# Altium schematic sheet AD.SchDoc(AD)
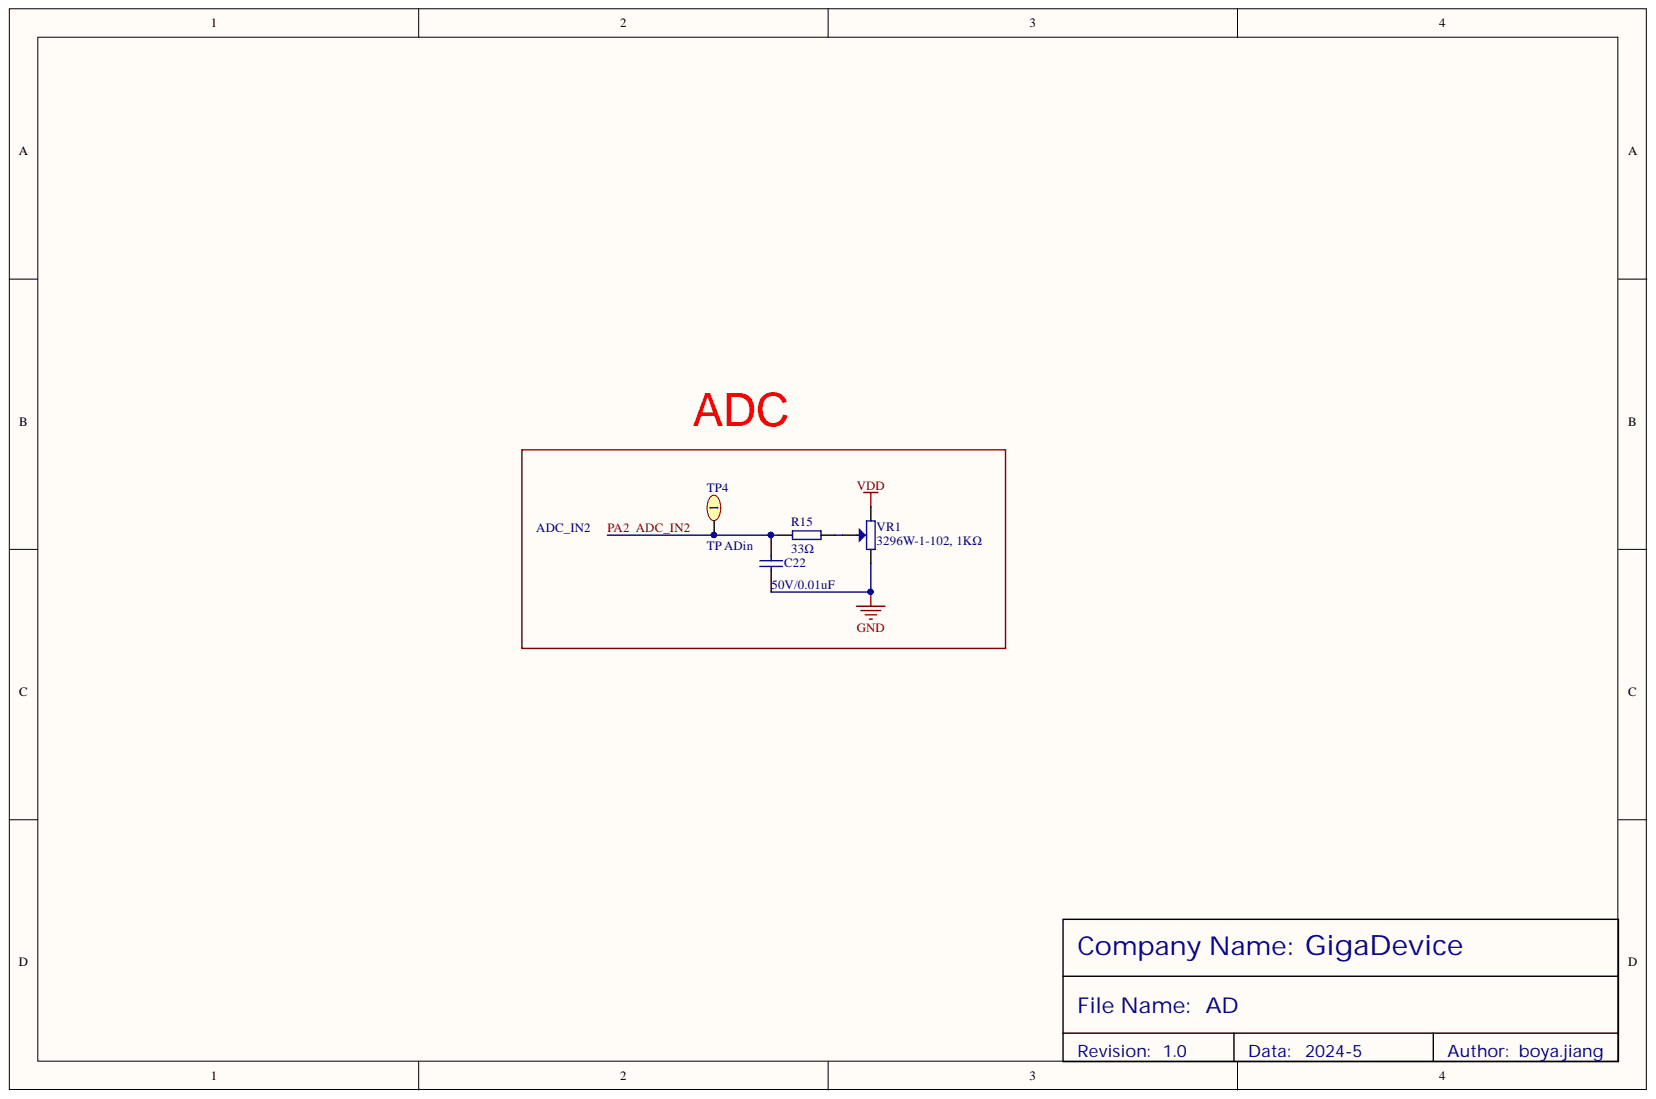
[diagram p0: AD.SchDoc]

## Parts
C22  50V/0.01uF  [0603-C]
R15  33Ω  [0603-R]
TP4  TP ADin  [SIP-1]
VR1  3296W-1-102, 1KΩ  [3296W]

## Nets
GND: C22.1 VR1.1
VDD: VR1.3
ADC_IN2: C22.2 R15.1 TP4.1
NetR15_2: R15.2 VR1.2

## Library data of the parts
C22 = 50V/0.1uF: 表贴电容; Component Kind: Standard; Library Name: KLTC-电容.SchLib
R15 = 470Ω: 表贴电阻; Component Kind: Standard; Library Name: KLTC-电阻.SchLib
TP4 = HEADER 1: 接点; Component Kind: Standard; Library Name: KLTC-按键及接插件.SchLib
VR1 = POT2: 直插可调电位器; Library Name: Schlib1.SchLib; Component Kind: Standard
